# Altium schematic sheet lv_power_12V_cv.SchDoc(lv_power_12V_cv)
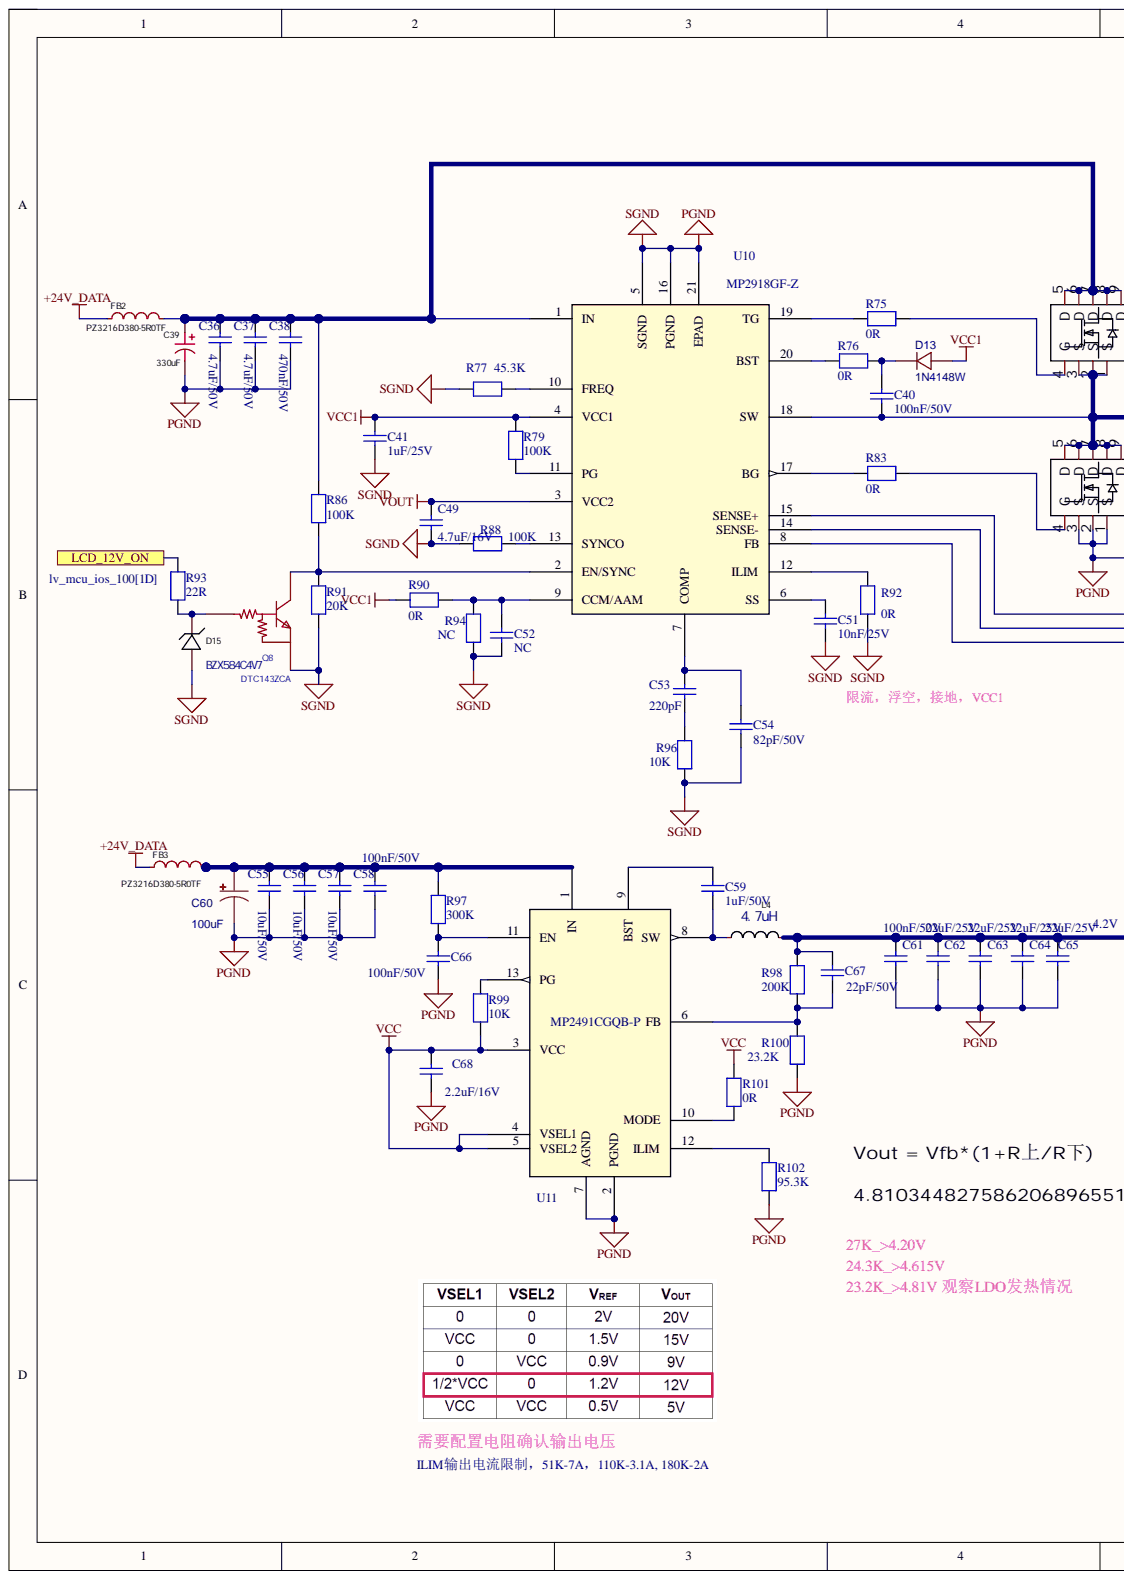
[diagram p0: lv_power_12V_cv.SchDoc, left]
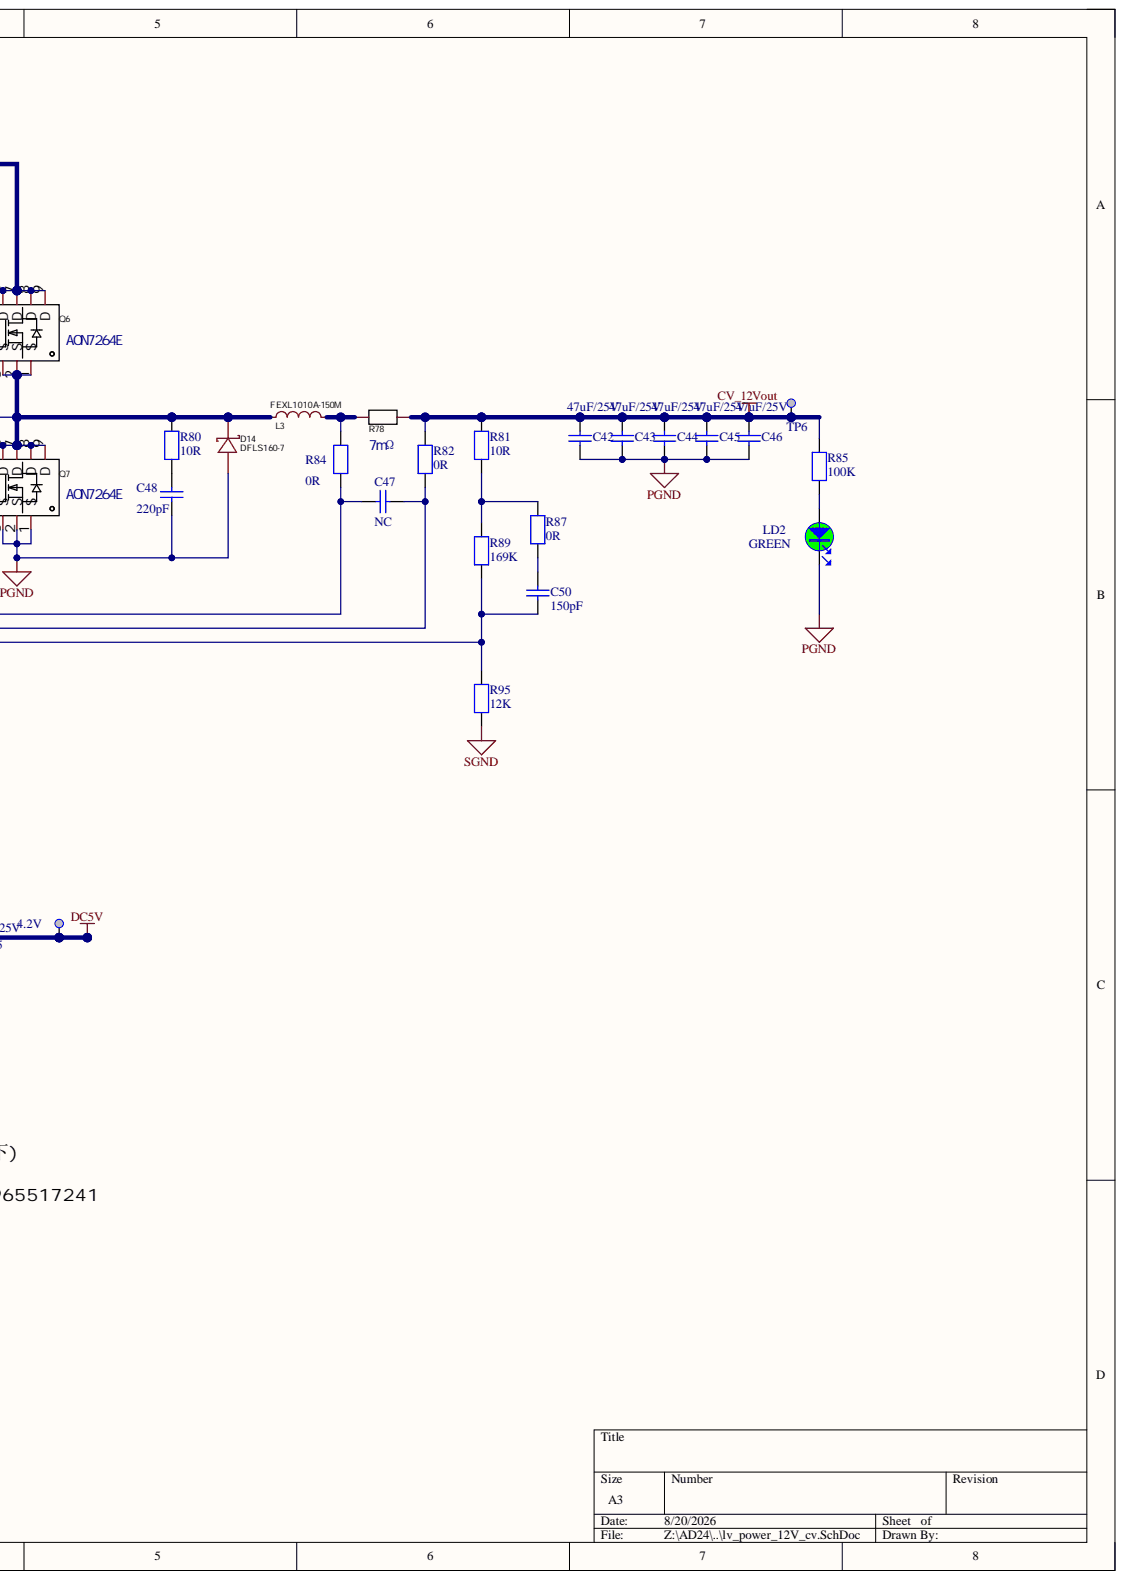
[diagram p1: lv_power_12V_cv.SchDoc, right]

## Parts
C36  4.7uF/50V  [C1206]
C37  4.7uF/50V  [C1206]
C38  470nF/50V  [C0603]
C39  330uF  [CAP-SMD_BD10.0-L10.3-W10.3-LS10.9-FD]
C40  100nF/50V  [C0402]
C41  1uF/25V  [C0402]
C42  47uF/25V  [1206]
C43  47uF/25V  [1206]
C44  47uF/25V  [1206]
C45  47uF/25V  [1206]
C46  47uF/25V  [1206]
C47  NC  [C0402]
C48  220pF  [C0603]
C49  4.7uF/16V  [C0603]
C50  150pF  [C0402]
C51  10nF/25V  [C0402]
C52  NC  [C0402]
C53  220pF  [C0402]
C54  82pF/50V  [C0402]
C55  10uF/50V  [C1206]
C56  10uF/50V  [C1206]
C57  10uF/50V  [C1206]
C58  100nF/50V  [C0402]
C59  1uF/50V  [C0805]
C60  100uF  [CAP-SMD_BD6.3-L6.6-W6.6-LS7.6-FD]
C61  100nF/50V  [C0402]
C62  22uF/25V  [C0805]
C63  22uF/25V  [C0805]
C64  22uF/25V  [C0805]
C65  22uF/25V  [C0805]
C66  100nF/50V  [C0402]
C67  22pF/50V  [C0402]
C68  2.2uF/16V  [C0603]
D13  1N4148W  [SOD-123_L2.8-W1.8-LS3.7-RD]
D14  DFLS160-7  [POWERDI-123_L2.8-W1.8-LS3.7-RD]
D15  BZX584C4V7  [SOD-523F_L1.2-W0.8-LS1.7-RD]
FB2  PZ3216D380-5R0TF  [L1206]
FB3  PZ3216D380-5R0TF  [L1206]
L3  FEXL1010A-150M  [IND-SMD_L11.9-W11.0]
L4  4.7uH  [IND-SMD_L7.2-W6.6_GPSR07X0]
LD2  value GREEN  [LED_0603_GREEN]  (hidden comment: LED_green)
Q6  AON7264E  [DFN-8_L3.0-W3.0-P0.65-BL_VBQFXXXX]
Q7  AON7264E  [DFN-8_L3.0-W3.0-P0.65-BL_VBQFXXXX]
Q8  DTC143ZCA  [SOT-416_L1.6-W0.8-P1.00-LS1.6-BR]
R75  0R  [R0603]
R76  0R  [R0603]
R77  45.3K  [R0402]
R78  7mΩ  [R2512]
R79  100K  [R0402]
R80  10R  [R0603]
R81  10R  [R0603]
R82  0R  [R0402]
R83  0R  [R0603]
R84  0R  [R0402]
R85  100K  [R0603]
R86  100K  [R0603]
R87  0R  [R0603]
R88  100K  [R0402]
R89  169K  [R0603]
R90  0R  [R0402]
R91  20K  [R0603]
R92  0R  [R0402]
R93  22R  [R0402]
R94  NC  [R0402]
R95  12K  [R0603]
R96  10K  [R0402]
R97  300K  [R0603]
R98  200K  [R0402]
R99  10K  [R0402]
R100  23.2K  [R0402]
R101  0R  [R0402]
R102  95.3K  [R0402]
TP6  Test Point  [TP_SMD_1MM]  (value not drawn)  (no BOM)
TP7  4.2V  [TP_SMD_1MM]  (no BOM)
U10  MP2918GF-Z  [TSSOP-20EP_MP2918_MNP]
U11  MP2491CGQB-P  [QFN-13_2p5MMx3MM_MNP]

## Ports
LCD_12V_ON

## Nets
+24V_DATA: FB2.1 FB3.1
CV_12Vout: C42.2 C43.2 C44.2 C45.2 C46.2 R78.2 R81.2 R82.2 R85.2 TP6.1
DC5V: C61.1 C62.2 C63.2 C64.2 C65.2 C67.2 L4.2 R98.1 TP7.1
PGND: C36.1 C37.1 C38.1 C39.2 C41.2 C42.1 C43.1 C44.1 C45.1 C46.1 C48.2 C49.1 C51.2 C52.2 C54.2 C55.1 C56.1 C57.1 C58.2 C60.2 C61.2 C62.1 C63.1 C64.1 C65.1 C66.1 C68.1 D14.2 D15.2 LD2.2 Q7.1(S) Q7.2(S) Q7.3(S) Q8.2 R77.1 R88.2 R91.2 R92.2 R94.2 R95.1 R96.2 R100.2 R102.2 U10.5(SGND) U10.16(PGND) U10.21(EPAD) U11.2(PGND) U11.7(AGND)
VCC: C68.2 R99.1 R101.2 U11.3(VCC) U11.4(VSEL1) U11.5(VSEL2)
VCC1: C41.1 D13.2 R79.2 R90.1 U10.4(VCC1)
VOUT: C49.2 U10.3(VCC2)
LCD_12V_ON: R93.1 ; port LCD_12V_ON
NetC36_2: C36.2 C37.2 C38.2 C39.1 FB2.2 Q6.5(D) Q6.6(D) Q6.7(D) Q6.8(D) Q6.9(D) R86.1 U10.1(IN)
NetC40_1: C40.1 D13.1 R76.2
NetC40_2: C40.2 D14.1 L3.1 Q6.1(S) Q6.2(S) Q6.3(S) Q7.5(D) Q7.6(D) Q7.7(D) Q7.8(D) Q7.9(D) R80.2 U10.18(SW)
NetC47_1: C47.1 R84.1 U10.15(SENSE+)
NetC47_2: C47.2 R82.1 U10.14(SENSE-)
NetC48_1: C48.1 R80.1
NetC50_1: C50.1 R89.1 R95.2 U10.8(FB)
NetC50_2: C50.2 R87.1
NetC51_1: C51.1 U10.6(SS)
NetC52_1: C52.1 R90.2 R94.1 U10.9(CCM/AAM)
NetC53_1: C53.1 C54.1 U10.7(COMP)
NetC53_2: C53.2 R96.1
NetC55_2: C55.2 C56.2 C57.2 C58.1 C60.1 FB3.2 R97.2 U11.1(IN)
NetC59_1: C59.1 U11.9(BST)
NetC59_2: C59.2 L4.1 U11.8(SW)
NetC66_2: C66.2 R97.1 U11.11(EN)
NetC67_1: C67.1 R98.2 R100.1 U11.6(FB)
NetD15_1: D15.1 Q8.1 R93.2
NetL3_2: L3.2 R78.1 R84.2
NetLD2_1: LD2.1 R85.1
NetQ6_4: Q6.4(G) R75.2
NetQ7_4: Q7.4(G) R83.2
NetQ8_3: Q8.3 R86.2 R91.1 U10.2(EN/SYNC)
NetR75_1: R75.1 U10.19(TG)
NetR76_1: R76.1 U10.20(BST)
NetR77_2: R77.2 U10.10(FREQ)
NetR79_1: R79.1 U10.11(PG)
NetR81_1: R81.1 R87.2 R89.2
NetR83_1: R83.1 U10.17(BG)
NetR88_1: R88.1 U10.13(SYNCO)
NetR92_1: R92.1 U10.12(ILIM)
NetR99_2: R99.2 U11.13(PG)
NetR101_1: R101.1 U11.10(MODE)
NetR102_1: R102.1 U11.12(ILIM)

## Library data of the parts
C36 C37 = Capacitor_2: 50V, X7R, 10%; Internal_Part_Number: MCD-00014; Manufacturer: muRata; Manufacturer_Part_Number: GRM31CR71H475KA12L; Value: 4.7uF; Component Kind: Standard; ComponentLink1Description: Datasheet; ComponentLink1URL: http://tunmcdsvn.tun.st.com/Component_Datasheet_Library/Trunk/Capacitors/Yageo_Multilayer_capacitor_X5R_series_100pF_to_100uF; Library Name: HiPin_Library_AD.IntLib
C38 = Capacitor_2: 50V, X7R, 10%; Manufacturer: YAGEO; Manufacturer_Part_Number: CC0603KRX7R9BB474; Component Kind: Standard; ComponentLink1Description: Datasheet; ComponentLink1URL: http://tunmcdsvn.tun.st.com/Component_Datasheet_Library/Trunk/Capacitors/Yageo_Multilayer_capacitor_X5R_series_100pF_to_100uF; Library Name: HiPin_Library_AD.IntLib
C39 = HV1V337M1010PZ: 330uF, 35V, 20%; Manufacturer: HONOR(荣誉); Add into BOM: yes; L: 10mm; Component Kind: Standard; Convert to PCB: yes; Datasheet: https://atta.szlcsc.com/upload/public/pdf/source/20240802/61683F5000C7CFED5606ABBE3CFBE0F5.pdf; Device: HV1V337M1010PZ; JLCPCB Part Class: Extended Part; LCSC Part Name: 330uF ±20% 35V; Library Name: HiPin_Library_AD.IntLib; Manufacturer Part: HV1V337M1010PZ; Operating Temperature: -55℃~+105℃; Rated Voltage: 35V; Supplier: LCSC; Supplier Footprint: SMD,D10xL10mm; Supplier Part: C5246558; Symbol: HV1V337M1010PZ; Tolerance: ±20%; Value: 330uF; φD: 10mm
C40 = Capacitor: 50V, 10%, X7R; Manufacturer_Part_Number: GRM155R61E105KA12D; Value: 100nF; Component Kind: Standard; ComponentLink1Description: Datasheet; ComponentLink1URL: http://tunmcdsvn.tun.st.com/Component_Datasheet_Library/Trunk/Capacitors/Yageo_Capacitor_NP0_16V-to-50V; Library Name: HiPin_Library_AD.IntLib; Package: C0402
C41 C52 = Capacitor: 25V, 10%, X5R; Manufacturer_Part_Number: GRM155R61E105KA12D; Value: 1uF; Component Kind: Standard; ComponentLink1Description: Datasheet; ComponentLink1URL: http://tunmcdsvn.tun.st.com/Component_Datasheet_Library/Trunk/Capacitors/Yageo_Capacitor_NP0_16V-to-50V; Library Name: HiPin_Library_AD.IntLib; Package: C0402
C42-C46 = Capacitor_3: 25V, X5R, 20%; Internal_Part_Number: MCD-00008; Manufacturer: muRata; Manufacturer_Part_Number: GRM31CR61E476ME44L; Value: 47uF; Component Kind: Standard; Library Name: HiPin_Library_AD.IntLib
C47 C48 = Capacitor: 50V, 5%,C0G; Manufacturer_Part_Number: GCM1885C1H221JA16D; Component Kind: Standard; ComponentLink1Description: Datasheet; ComponentLink1URL: http://tunmcdsvn.tun.st.com/Component_Datasheet_Library/Trunk/Capacitors/Yageo_Capacitor_NP0_16V-to-50V; Library Name: HiPin_Library_AD.IntLib
  C47: Package: C0402
C49 = Capacitor_1: 16V, X7S, 10%; Internal_Part_Number: MCD-00009; Manufacturer: muRata; Manufacturer_Part_Number: GRM188C71C475KE21D; Value: 4.7uF; Component Kind: Standard; ComponentLink1Description: Yageo_Capacitor_X5R-series.pdf; ComponentLink1URL: http://tunmcdsvn.tun.st.com/Component_Datasheet_Library/Trunk/Capacitors/Yageo_Multilayer_capacitor_X5R_series_100pF_to_100uF; Library Name: HiPin_Library_AD.IntLib; Package: C0603
C50 = Capacitor: 50V, 5%,C0G; Manufacturer_Part_Number: GRM1555C1H151JA01D; Component Kind: Standard; ComponentLink1Description: Datasheet; ComponentLink1URL: http://tunmcdsvn.tun.st.com/Component_Datasheet_Library/Trunk/Capacitors/Yageo_Capacitor_NP0_16V-to-50V; Library Name: HiPin_Library_AD.IntLib; Package: C0402
C51 = Capacitor: 25V, 5%, C0G; Manufacturer_Part_Number: GRM1555C1E103JE01D; Component Kind: Standard; ComponentLink1Description: Datasheet; ComponentLink1URL: http://tunmcdsvn.tun.st.com/Component_Datasheet_Library/Trunk/Capacitors/Yageo_Capacitor_NP0_16V-to-50V; Library Name: HiPin_Library_AD.IntLib; Package: C0402
C53 = Capacitor: 50V, 5%,C0G; Manufacturer_Part_Number: GRM1555C1H221JA01D; Component Kind: Standard; ComponentLink1Description: Datasheet; ComponentLink1URL: http://tunmcdsvn.tun.st.com/Component_Datasheet_Library/Trunk/Capacitors/Yageo_Capacitor_NP0_16V-to-50V; Library Name: HiPin_Library_AD.IntLib; Package: C0402
C54 = Capacitor: 50V, 1%, NP0; Manufacturer: YAGEO; Manufacturer_Part_Number: CC0402FRNPO9BN820; Component Kind: Standard; Library Name: HiPin_Library_AD.IntLib; Package: C0402
C55-C57 = Capacitor_2: 35V, X5R, 10%; Internal_Part_Number: MCD-00014; Manufacturer: muRata; Manufacturer_Part_Number: GRM31CR61H106KA12L; Value: 10uF; Component Kind: Standard; ComponentLink1Description: Datasheet; ComponentLink1URL: http://tunmcdsvn.tun.st.com/Component_Datasheet_Library/Trunk/Capacitors/Yageo_Multilayer_capacitor_X5R_series_100pF_to_100uF; Library Name: HiPin_Library_AD.IntLib
C58 C61 = Capacitor: 50V, 10%, X7R; Internal_Part_Number: MCD-00003; Manufacturer: muRata; Manufacturer_Part_Number: GRM155R71H104KE14D; Value: 100nF; Component Kind: Standard; ComponentLink1Description: Datasheet; ComponentLink1URL: http://tunmcdsvn.tun.st.com/Component_Datasheet_Library/Trunk/Capacitors/Yageo_Capacitor_NP0_16V-to-50V; Library Name: HiPin_Library_AD.IntLib; Package: C0402
C59 = Capacitor: 50V, 10%, X7R; Internal_Part_Number: MCD-00003; Manufacturer_Part_Number: GRM21BR71H105KA12L; Value: 1uF; Component Kind: Standard; ComponentLink1Description: Datasheet; ComponentLink1URL: http://tunmcdsvn.tun.st.com/Component_Datasheet_Library/Trunk/Capacitors/Yageo_Capacitor_NP0_16V-to-50V; Library Name: HiPin_Library_AD.IntLib; Package: C0805
C60 = 100uF: 50V, 20%, 229mA@120Hz; Manufacturer: Nichicon; Manufacturer_Part_Number: RVT1H101M0607; Component Kind: Standard; Library Name: HiPin_Library_AD.IntLib
C62-C65 = Capacitor_2: 25V, X5R, 10%; Internal_Part_Number: MCD-00014; Manufacturer: muRata; Manufacturer_Part_Number: GRM21BR61E226ME44L; Value: 22uF; Component Kind: Standard; ComponentLink1Description: Datasheet; ComponentLink1URL: http://tunmcdsvn.tun.st.com/Component_Datasheet_Library/Trunk/Capacitors/Yageo_Multilayer_capacitor_X5R_series_100pF_to_100uF; Library Name: HiPin_Library_AD.IntLib
C66 = Capacitor: 50V, 10%, X7R; Manufacturer: muRata; Manufacturer_Part_Number: GRM155R71H104KE14D; Component Kind: Standard; ComponentLink1Description: Datasheet; ComponentLink1URL: http://tunmcdsvn.tun.st.com/Component_Datasheet_Library/Trunk/Capacitors/Yageo_Capacitor_NP0_16V-to-50V; Library Name: HiPin_Library_AD.IntLib; Package: C0402
C67 = Capacitor: 50V, 10%, NP0; Manufacturer: muRata; Manufacturer_Part_Number: GRM1555C1H220JA01D; Component Kind: Standard; Library Name: HiPin_Library_AD.IntLib
C68 = Capacitor_1: 16V, X5R, 10%; Internal_Part_Number: MCD-00009; Manufacturer: muRata; Manufacturer_Part_Number: GRM155R61E225KE11D; Value: 2.2uF; Component Kind: Standard; ComponentLink1Description: Yageo_Capacitor_X5R-series.pdf; ComponentLink1URL: http://tunmcdsvn.tun.st.com/Component_Datasheet_Library/Trunk/Capacitors/Yageo_Multilayer_capacitor_X5R_series_100pF_to_100uF; Library Name: HiPin_Library_AD.IntLib; Package: C0603
D13 = 1N4148W-C84367: 开关二极管,1.25V,100V,150mA; BOM_Manufacturer: MDD; BOM_JLCPCB Part Class: Extended Part; BOM_Manufacturer Part: 1N4148W; BOM_Supplier: LCSC; BOM_Supplier Part: C84367; Component Kind: Standard; Library Name: HiPin_Library_AD.IntLib; spicePre: D; spiceSymbolName: 1N4148W-C84367
D14 = DFLS160-7: 肖特基二极管60V,1A,500mV@1A; Manufacturer: DIODES(美台); Add into BOM: yes; Reverse Voltage (Vr): 60V; Component Kind: Standard; Convert to PCB: yes; Datasheet: https://atta.szlcsc.com/upload/public/pdf/source/20181114/C177023_80F0A2460033305602CFDDCB3101FB4E.pdf; Device: DFLS160-7; Forward Voltage (Vf@If): 500mV@1A; JLCPCB Part Class: Extended Part; LCSC Part Name: 电压:60V 电流:1A; Library Name: HiPin_Library_AD.IntLib; Manufacturer Part: DFLS160-7; PCB3D: POWERDI-123_L2.8-W1.8-H1.0-LS3.7; Rectified Current: 1A; Supplier: LCSC; Supplier Footprint: Power-DI-123; Supplier Part: C177023; Symbol: DFLS160-7
D15 = LM5Z3V6T1G: 4.8~5.4V, 200mW; Power Dissipation: 500mW; Component Kind: Standard; Library Name: HiPin_Library_AD.IntLib; Manufacturer Part: BZX584C4V7; PCB3D: SOD-123_L2.7-W1.6-H1.4-LS3.7; Supplier Footprint: SOD-123; Tolerance: -
FB2 FB3 = BLM31PG601SN1L: 38Ω@100MHz 5A 15mΩ ±25%; Component Kind: Standard; Library Name: HiPin_Library_AD.IntLib
L3 = FEXL1010A-150M: 15uH,±20%,13.8A; Manufacturer: cjiang(长江微电); Add into BOM: yes; DC Resistance (DCR): 19.3mΩ; Saturation Current (Isat): 15.5A; Type: 一体成型电感; Component Kind: Standard; Convert to PCB: yes; Datasheet: https://atta.szlcsc.com/upload/public/pdf/source/20240409/B9FA7ECCC3220F3F7C7BE8F7B1FCE238.pdf; Device: FEXL1010A-150M; JLCPCB Part Class: Extended Part; LCSC Part Name: 15uH ±20% 15.5A; Library Name: HiPin_Library_AD.IntLib; Manufacturer Part: FEXL1010A-150M; PCB3D: IND-SMD_L11.9-W11.0; Rated Current: 13.8A; Ratings: AEC-Q200; Supplier: LCSC; Supplier Footprint: SMD,11.9x11mm; Supplier Part: C5378579; Symbol: FEXL1010A-150M; Tolerance: ±20%; Value: 15uH
L4 = CYA0630-10UH: 22.9mΩ，20%, 8A; Manufacturer: PROD; Manufacturer Part: PSPMAA0605H-4R7M-ANP; DC Resistance (DCR): 29mΩ; Tolerance: ±20%; Component Kind: Standard; Library Name: HiPin_Library_AD.IntLib; PCB3D: IND-SMD_L7.2-W6.6-H3.0_GPSR07X0; Supplier Footprint: SMD,6.6x7.2mm; Value: 4.7uH
LD2 = LED_green: LED, Green, 0603; Internal_Part_Number: MCD-00050; Manufacturer: EVERLIGHT; Manufacturer_Part_Number: 19-213SYGC/S530-E2/TR8; Part_Type: Display; ECCN_EU: NEC; ECCN_US: EAR99; Provided_By: SUBCO; Component Kind: Standard; ComponentLink1Description: Datasheet; ComponentLink1URL: http://tunmcdsvn.tun.st.com/Component_Datasheet_Library/Trunk/Display/Everlight_SMD-LED-GREEN_19-213SYGC-S530-XX-TR8-v3.doc; Library Name: mb1367.IntLib; Package: LED 0603; Status: Released
Q6 Q7 = HYG045N03LA1C1: NMOS; Manufacturer: HUAYI(����΢); Add into BOM: yes; Continuous Drain Current (Id): 50A; Drain Source On Resistance (RDS(on)@Vgs,Id): 3.9m��@10V,20A; Drain Source Voltage (Vdss): 30V; Gate Threshold Voltage (Vgs(th)@Id): 1.6V@250uA; Input Capacitance (Ciss@Vds): 2.106nF@25V; Power Dissipation (Pd): 23W; Reverse Transfer Capacitance (Crss@Vds): 228pF@25V; Total Gate Charge (Qg@Vgs): 46.2nC@10V; Type: N����; Component Kind: Standard; Convert to PCB: yes; Datasheet: https://atta.szlcsc.com/upload/public/pdf/source/20211028/5F8459D5B1D3B8F85ED46FBAED5B3F3F.pdf; Device: HYG045N03LA1C1; JLCPCB Part Class: Extended Part; LCSC Part Name: N���� ��ѹ:30V ����:50A; Library Name: HiPin_Library_AD.IntLib; Manufacturer Part: HYG045N03LA1C1; Operating Temperature: -55��~+175��@(Tj); Supplier: LCSC; Supplier Footprint: DFN-8(3x3); Supplier Part: C2911691; Symbol: HYG045N03LA1C1
Q8 = DTC043ZEBTL: NPN 三极管; Manufacturer: ROHM(罗姆); Add into BOM: yes; Collector Current (Ic): 100mA; Collector-Emitter Breakdown Voltage (Vceo): 50V; DC Current Gain (hFE@Ic,Vce): 80@5mA,10V; Input Resistor: 4.7kΩ; Input Voltage (VI(on)@Ic,Vce): 1.1V@5mA,0.3V; Output Voltage (VO(on)@Io/Ii): 150mV@5mA,0.5mA; Power Dissipation (Pd): 150mW; Resistor Ratio: 10; Component Kind: Standard; Convert to PCB: yes; Datasheet: https://atta.szlcsc.com/upload/public/pdf/source/20230825/AFF9D3CF4DD067520C5D7C04408FCBF2.pdf; Device: DTC043ZEBTL; JLCPCB Part Class: Extended Part; LCSC Part Name: 耐压:50V 电流:100mA; Library Name: HiPin_Library_AD.IntLib; Manufacturer Part: DTC043ZEBTL; Operating Temperature: -55℃~+150℃; PCB3D: SOT-416-3P_L1.6-W0.8-H0.9-LS1.6-P1.00; Supplier: LCSC; Supplier Footprint: SOT-416FL; Supplier Part: C96459; Symbol: DTC043ZEBTL; Transistor Type: -
R75-R77 R79-R95 R97 R98 R101 R102 = RES: 1%; Value: 10K; Component Kind: Standard; Library Name: HiPin_Library_AD.IntLib
R78 = PA2512FKE070R005E: R2512-2W-7mR-1%-15ppm; Manufacturer: Milliohm(毫欧); Component Kind: Standard; Library Name: HiPin_Library_AD.IntLib; Manufacturer Part: R2512-2W-7mR-1%-15ppm; PCB3D: RES-SMD_L6.4-W3.2_C500733
R96 R99 R100 = RES: 1%; Component Kind: Standard; Library Name: HiPin_Library_AD.IntLib
  R96 R99: Value: 10K
TP6 TP7 = MCD-00074: Test Point 1mm; Part_Type: Mechanical; Database Table Name: MCD_PARTS$; ComponentLink1Description: Datasheet; ComponentLink1URL: http://na; ECCN_EU: n/a; ECCN_US: n/a; Internal_Part_Number: MCD-00074; Library Name: MCD_PARTS_database.DbLib; Manufacturer: n/a; Manufacturer_Part_Number: n/a; Provided_By: n/a; Status: Released
U10 = MP2918GF-Z: No Description Available; Mfr_Name: Monolithic Power Systems; Component Kind: Standard; Library Name: HiPin_Library_AD.IntLib; RefDes: RefDes; Type: Type
U11 = MP2491CGQB-P: No Description Available; Mfr_Name: Monolithic Power Systems; Component Kind: Standard; Library Name: HiPin_Library_AD.IntLib; RefDes: RefDes; Type: Type
